# Altium schematic sheet extra10_voltage_monitor.SchDoc
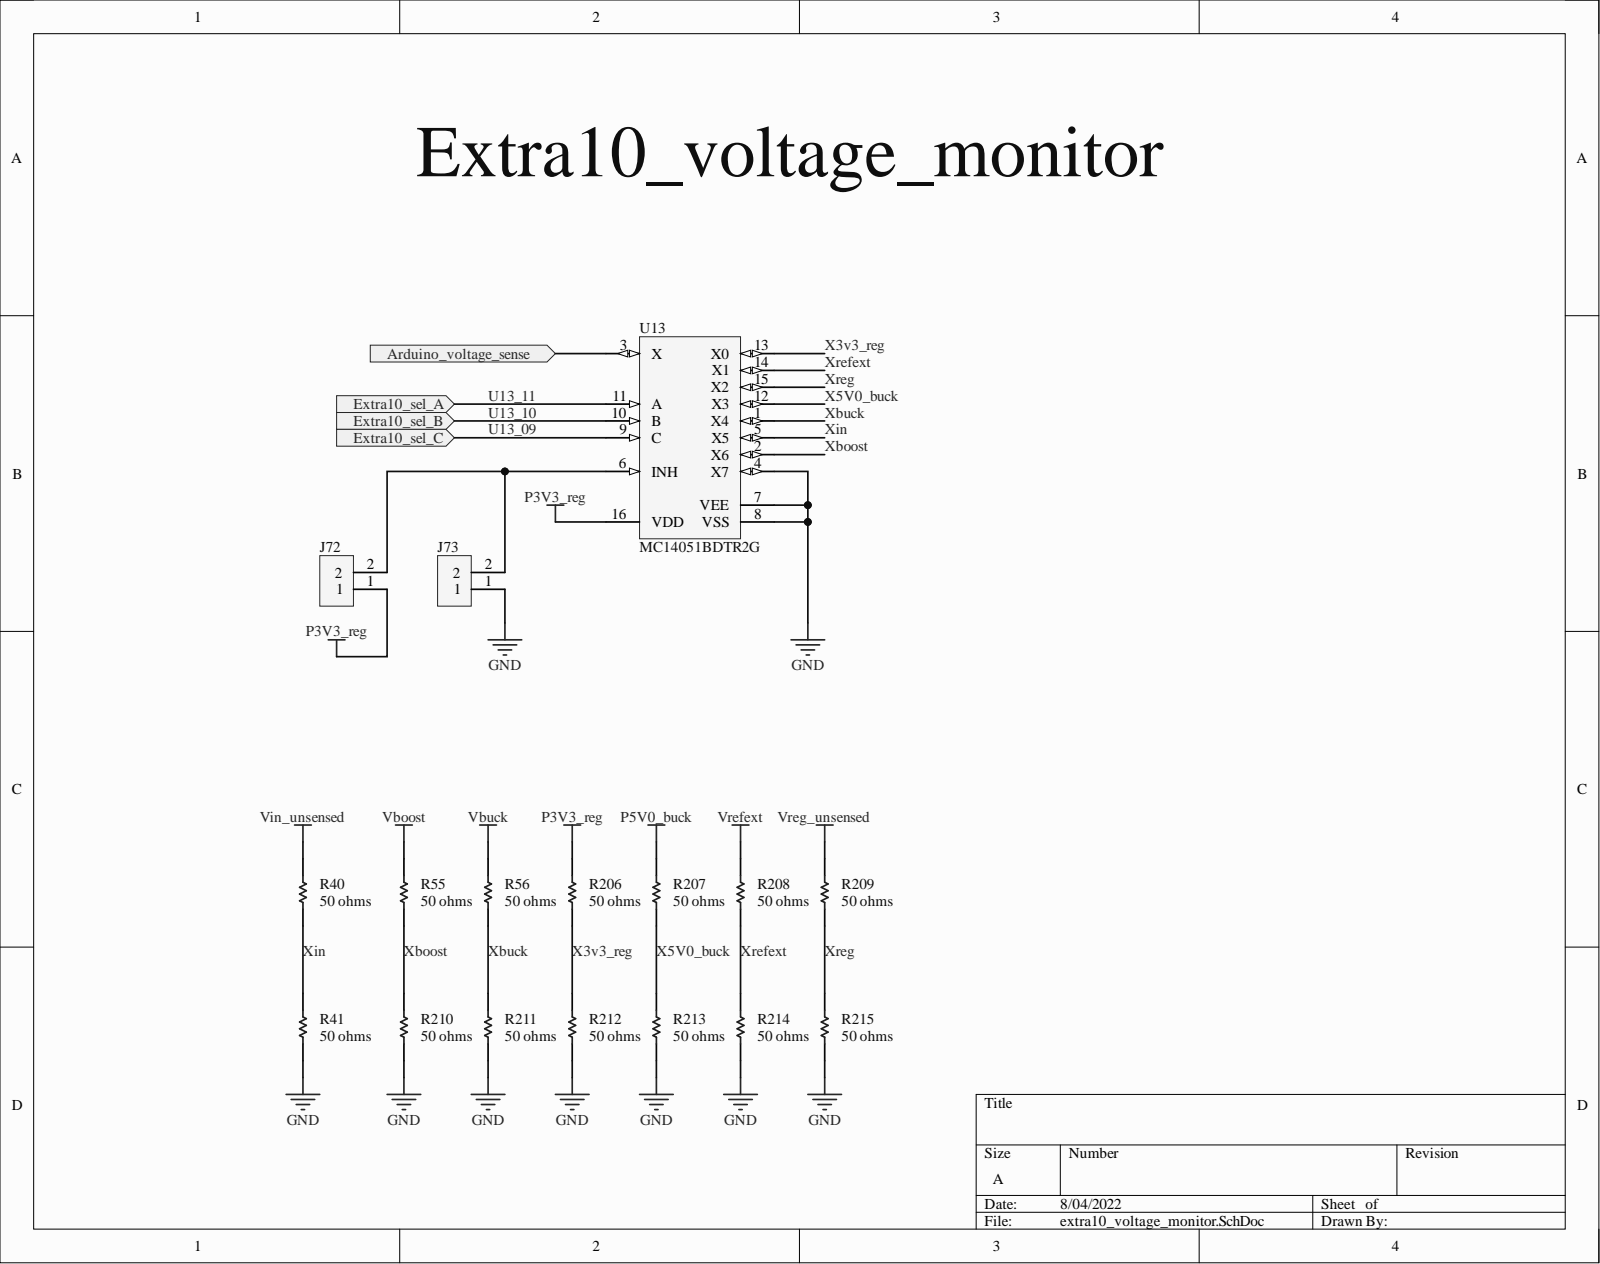
[diagram p0: extra10_voltage_monitor.SchDoc]

## Parts
J72  (no value)  [PCB-omgsbkhp90n7lpri88og-1]
J73  (no value)  [PCB-omgsbkhp90n7lpri88og-1]
R40  50 ohms  [PCB-4097432-1]
R41  50 ohms  [PCB-4097432-1]
R55  50 ohms  [PCB-4097432-1]
R56  50 ohms  [PCB-4097432-1]
R206  50 ohms  [PCB-4097432-1]
R207  50 ohms  [PCB-4097432-1]
R208  50 ohms  [PCB-4097432-1]
R209  50 ohms  [PCB-4097432-1]
R210  50 ohms  [PCB-4097432-1]
R211  50 ohms  [PCB-4097432-1]
R212  50 ohms  [PCB-4097432-1]
R213  50 ohms  [PCB-4097432-1]
R214  50 ohms  [PCB-4097432-1]
R215  50 ohms  [PCB-4097432-1]
U13  MC14051BDTR2G  [ONSC-TSSOP-16-948F-01_V]

## Ports
Arduino_voltage_sense, Extra10_sel_A, Extra10_sel_B, Extra10_sel_C

## Nets
GND: J73.1 R41.1 R210.1 R211.1 R212.1 R213.1 R214.1 R215.1 U13.4(X7) U13.7(VEE) U13.8(VSS)
P3V3_reg: J72.1 R206.2 U13.16(VDD)
P5V0_buck: R207.2
Vboost: R55.2
Vbuck: R56.2
Vin_unsensed: R40.2
Vrefext: R208.2
Vreg_unsensed: R209.2
Arduino_voltage_sense: U13.3(X) ; port Arduino_voltage_sense
U13_09: U13.9(C) ; port Extra10_sel_C
U13_10: U13.10(B) ; port Extra10_sel_B
U13_11: U13.11(A) ; port Extra10_sel_A
X3v3_reg: R206.1 R212.2 U13.13(X0)
X5V0_buck: R207.1 R213.2 U13.12(X3)
Xboost: R55.1 R210.2 U13.2(X6)
Xbuck: R56.1 R211.2 U13.1(X4)
Xin: R40.1 R41.2 U13.5(X5)
Xrefext: R208.1 R214.2 U13.14(X1)
Xreg: R209.1 R215.2 U13.15(X2)
NetJ72_2: J72.2 J73.2 U13.6(INH)

## Library data of the parts
J72 J73 = CMP-748fbcb73d6bab48-30: Pitch 2.54 mm, Single Row, 2pins; Component Kind: Standard; Library Name: My Personal Space
R40 R41 R55 R56 R206-R215 = CMP-03acc8c67e5fdc6b-1: RT Series 0805 0.125 W 15 kOhm ±1 % ±50 ppm/°C SMT Thin Film Chip Resistor; Manufacturer Part Number: RT0805FRE0715KL; Case/Package (SI): 2012; Lead-Free Status: Lead Free; Power Rating: 0.125 W; Resistance: 15000.0 Ω; Resistance Tolerance: ±1%; Voltage Rating (DC): 150.0 V; Case/Package: 0805; Component Kind: Standard; Library Name: My Personal Space; Mounting Style: Surface Mount; Number of Pins: 2; Octopart URL: http://octopart.com/rt0805fre0715kl-yageo-39868565; OctopartID: 03acc8c67e5fdc6b; Packaging: Tape, Tape & Reel (TR); REACH SVHC Compliance: No SVHC; RoHS: Compliant; Size-Height: 0.0005 m; Size-Length: 0.002 m; Size-Width: 0.00125 m
U13 = CMP-1172680-2: Analog Multiplexer / Demultiplexer, 3 to 18 V, -55 to 125 degC, 16-Pin TSSOP, Pb-Free, Tape and Reel; Contacts Type: SP8T; Lead-Free Status: Lead Free; Case/Package: TSSOP; Component Kind: Standard; Halogen Free Status: Halogen Free; Library Name: My Personal Space; Lifecycle Status: Active; Manufacturer Part Number: MC14051BDTR2G; Mounting Style: Surface Mount; Number of Pins: 16; Octopart Url: https://octopart.com/mc14051bdtr2g-on+semiconductor-473533; OctopartID: 02a1cbac1e7add66; Packaging: Cut Tape (CT); RoHS: Compliant
